# Altium schematic sheet 02 DAC_AD5760.SchDoc
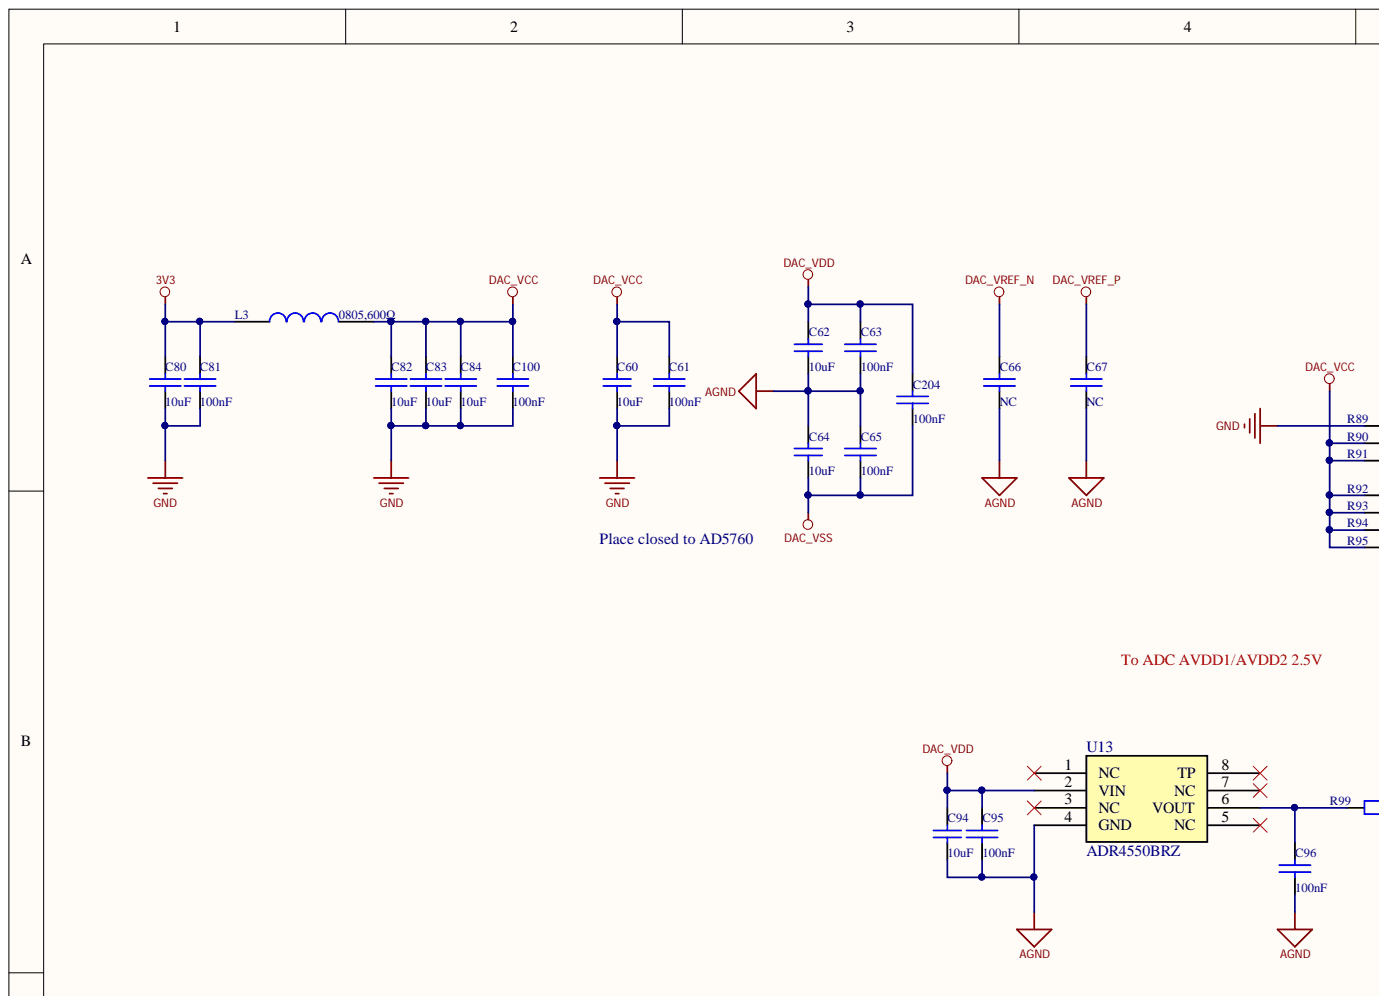
[diagram p0: 02 DAC_AD5760.SchDoc, top left]
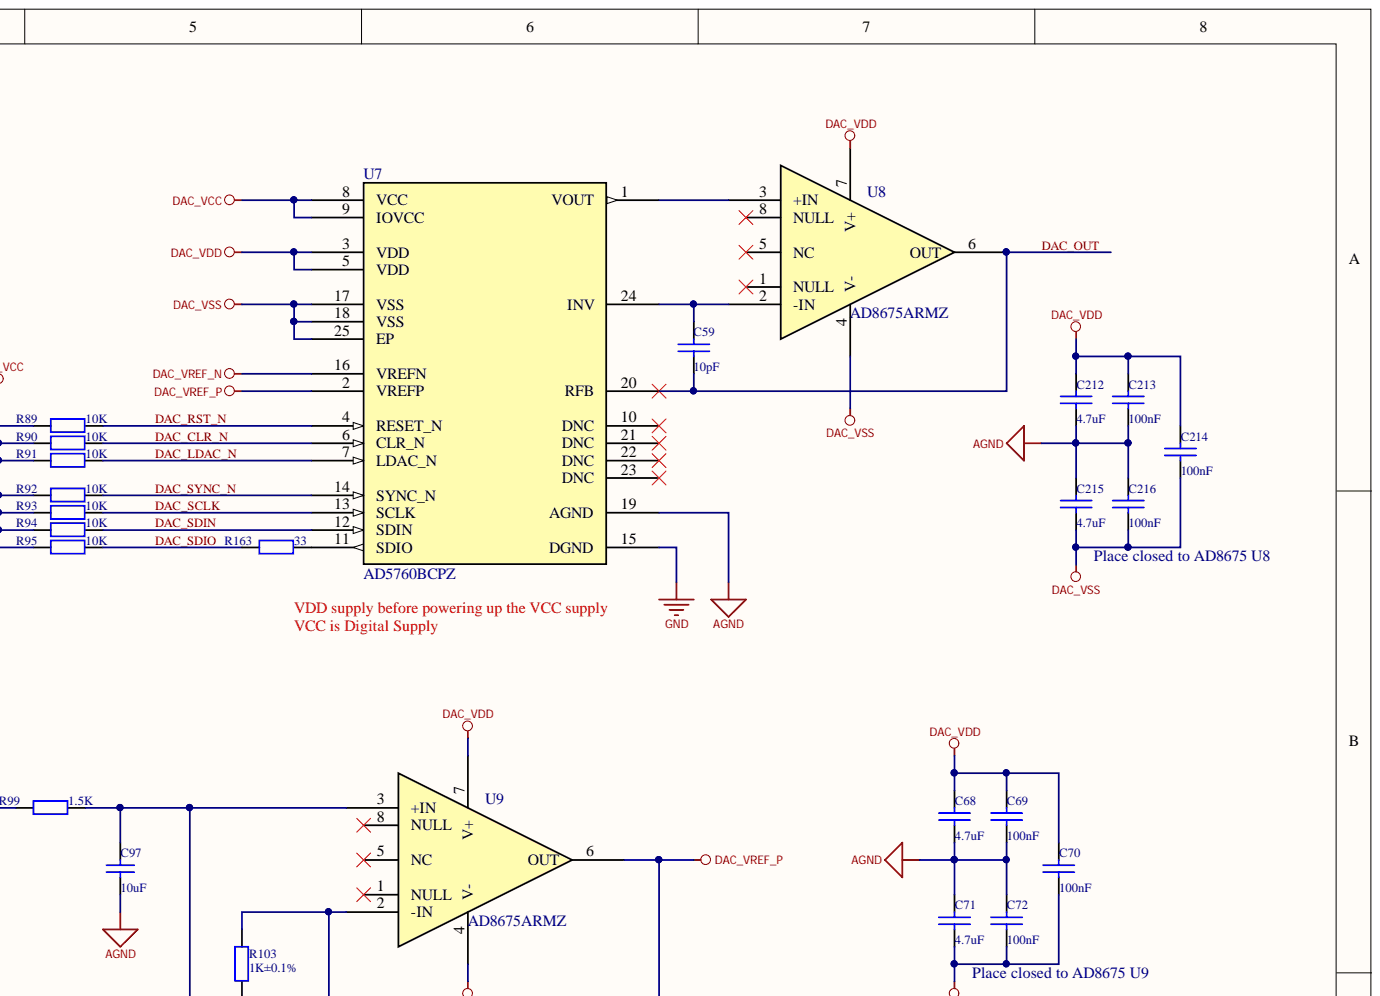
[diagram p1: 02 DAC_AD5760.SchDoc, top right]
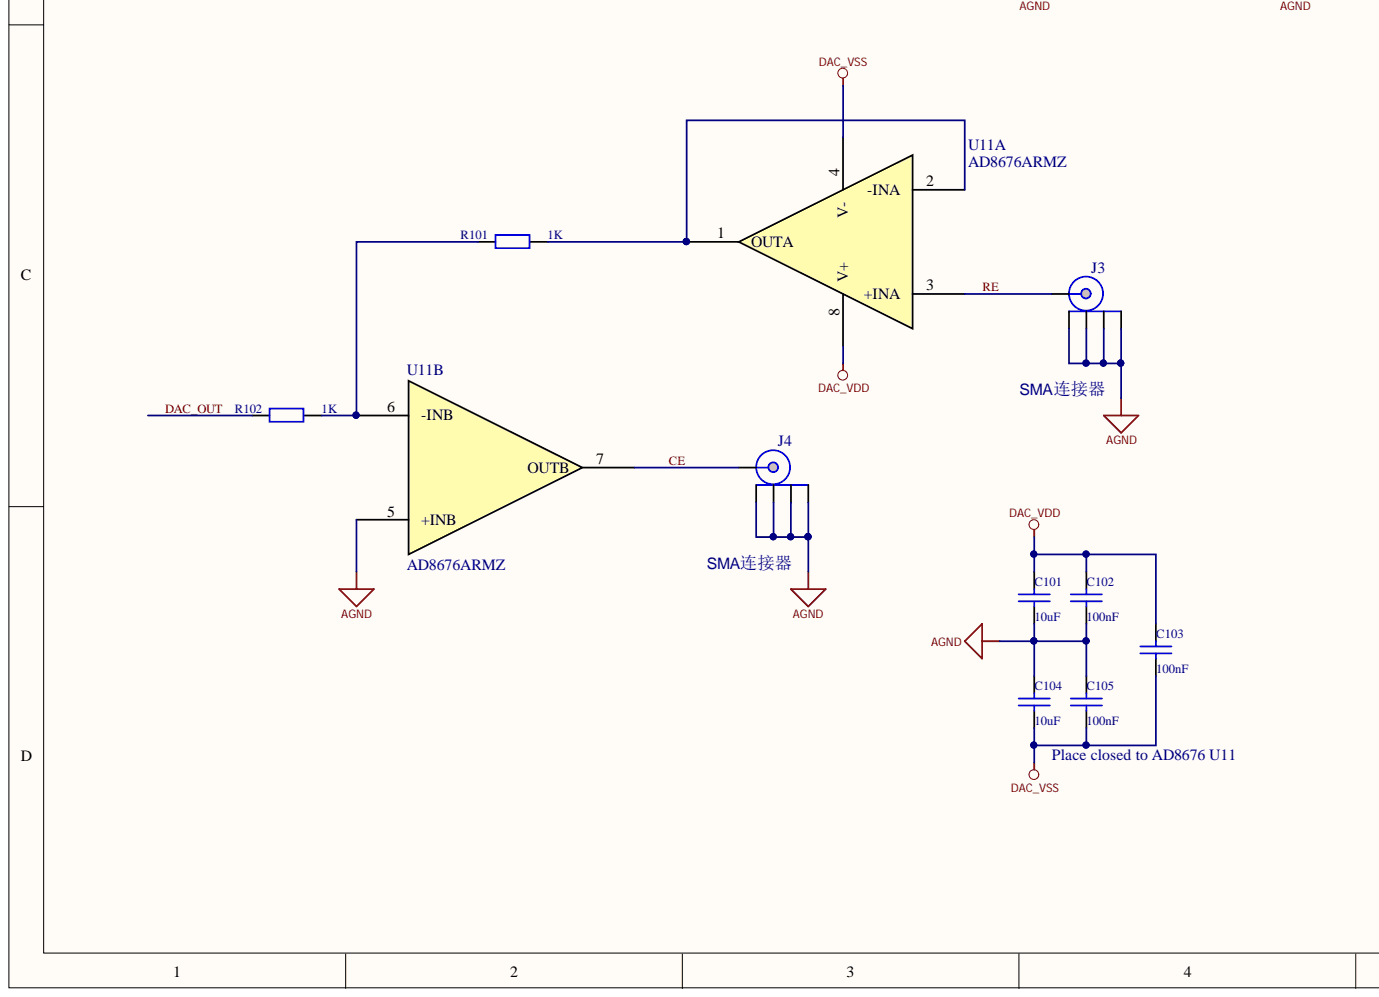
[diagram p2: 02 DAC_AD5760.SchDoc, bottom left]
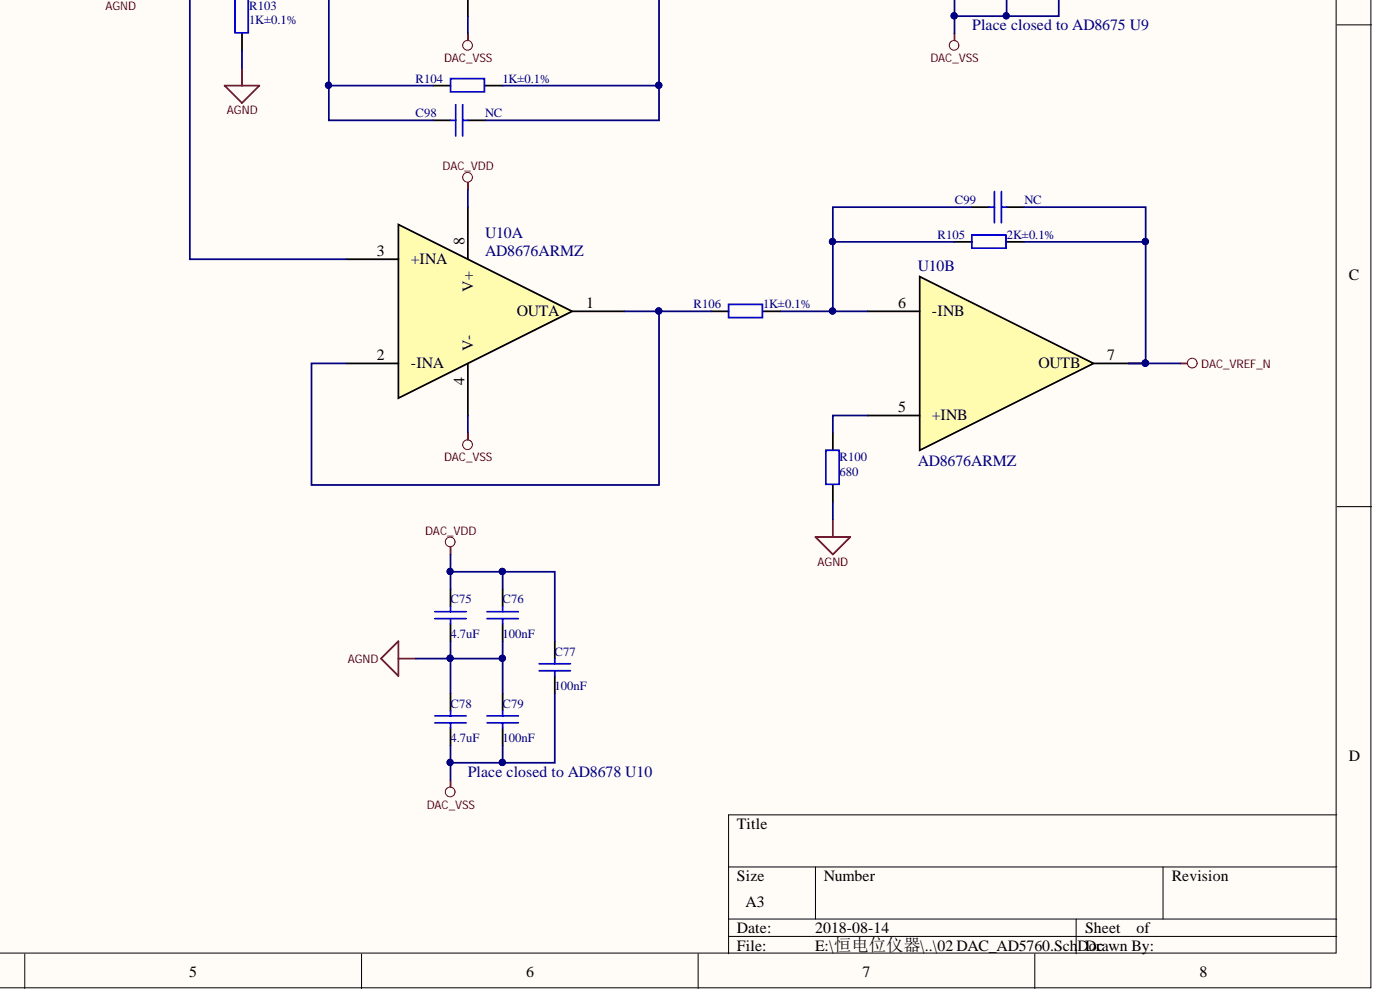
[diagram p3: 02 DAC_AD5760.SchDoc, bottom right]

## Parts
C59  10pF  [SC0402]
C60  10uF  [SC0805]
C61  100nF  [SC0603]
C62  10uF  [SC0805]
C63  100nF  [SC0603]
C64  10uF  [SC0805]
C65  100nF  [SC0603]
C66  220pF  [SC0402]  (value not drawn)
C67  220pF  [SC0402]  (value not drawn)
C68  4.7uF  [SC0603]
C69  100nF  [SC0402]
C70  100nF  [SC0402]
C71  4.7uF  [SC0603]
C72  100nF  [SC0402]
C75  4.7uF  [SC0603]
C76  100nF  [SC0402]
C77  100nF  [SC0402]
C78  4.7uF  [SC0603]
C79  100nF  [SC0402]
C80  10uF  [SC0603]
C81  100nF  [SC0402]
C82  10uF  [SC0603]
C83  10uF  [SC0603]
C84  10uF  [SC0603]
C94  10uF  [SC0805]
C95  100nF  [SC0603]
C96  100nF  [SC0603]
C97  10uF  [SC0805]
C98  100nF  [SC0603]  (value not drawn)
C99  100nF  [SC0603]  (value not drawn)
C100  100nF  [SC0402]
C101  10uF  [SC0603]
C102  100nF  [SC0402]
C103  100nF  [SC0402]
C104  10uF  [SC0603]
C105  100nF  [SC0402]
C204  100nF  [SC0402]
C212  4.7uF  [SC0603]
C213  100nF  [SC0402]
C214  100nF  [SC0402]
C215  4.7uF  [SC0603]
C216  100nF  [SC0402]
J3  SMA连接器  [SMA]
J4  SMA连接器  [SMA]
L3  0805,600Ω  [SL0805]
R89  10K±1%  value 10K  [SR0402]
R90  10K±1%  value 10K  [SR0402]
R91  10K±1%  value 10K  [SR0402]
R92  10K±1%  value 10K  [SR0402]
R93  10K±1%  value 10K  [SR0402]
R94  10K±1%  value 10K  [SR0402]
R95  10K±1%  value 10K  [SR0402]
R99  value 1.5K  [SR0805]  (hidden comment: 1.5K±1%)
R100  value 680  [SR0603]  (hidden comment: 680±1%)
R101  value 1K  [SR0603]  (hidden comment: 1K±1%)
R102  value 1K  [SR0603]  (hidden comment: 1K±1%)
R103  1K±0.1%  [SR0805]
R104  1K±0.1%  [SR0805]
R105  2K±0.1%  [SR0805]
R106  1K±0.1%  [SR0805]
R163  value 33  [SR0402]  (hidden comment: 33±1%)
U7  AD5760BCPZ  [CP-24-5]
U8  AD8675ARMZ  [RM_8]
U9  AD8675ARMZ  [RM_8]
U10  AD8676ARMZ  [RM_8]  (parts A,B)
U11  AD8676ARMZ  [RM_8]  (parts A,B)
U13  ADR4550BRZ  [R_8]

## Nets
3V3: C80.2 C81.2 L3.1
AGND: C62.2 C63.2 C64.2 C65.2 C66.1 C67.1 C68.1 C69.1 C71.2 C72.2 C75.1 C76.1 C78.2 C79.2 C94.2 C95.2 C96.2 C97.2 C101.1 C102.1 C104.2 C105.2 C212.1 C213.1 C215.2 C216.2 J3.2 J3.3 J3.4 J3.5 J4.2 J4.3 J4.4 J4.5 R100.1 R103.1 U7.19(AGND) U11.5(+INB) U13.4(GND)
DAC_VCC: C60.1 C61.1 C82.2 C83.2 C84.2 C100.2 L3.2 R90.2 R91.2 R92.2 R93.2 R94.2 R95.2 U7.8(VCC) U7.9(IOVCC)
DAC_VDD: C62.1 C63.1 C68.2 C69.2 C70.2 C75.2 C76.2 C77.2 C94.1 C95.1 C101.2 C102.2 C103.2 C204.2 C212.2 C213.2 C214.2 U7.3(VDD) U7.5(VDD) U8.7(V+) U9.7(V+) U10.8(V+) U11.8(V+) U13.2(VIN)
DAC_VREF_N: C66.2 C99.2 R105.2 U7.16(VREFN) U10.7(OUTB)
DAC_VREF_P: C67.2 C98.2 R104.2 U7.2(VREFP) U9.6(OUT)
DAC_VSS: C64.1 C65.1 C70.1 C71.1 C72.1 C77.1 C78.1 C79.1 C103.1 C104.1 C105.1 C204.1 C214.1 C215.1 C216.1 U7.17(VSS) U7.18(VSS) U7.25(EP) U8.4(V-) U9.4(V-) U10.4(V-) U11.4(V-)
GND: C60.2 C61.2 C80.1 C81.1 C82.1 C83.1 C84.1 C100.1 R89.2 U7.15(DGND)
CE: J4.1 U11.7(OUTB)
DAC_CLR_N: R90.1 U7.6(CLR_N)
DAC_LDAC_N: R91.1 U7.7(LDAC_N)
DAC_OUT: C59.1 R102.1 U7.20(RFB) U8.6(OUT)
DAC_RST_N: R89.1 U7.4(RESET_N)
DAC_SCLK: R93.1 U7.13(SCLK)
DAC_SDIN: R94.1 U7.12(SDIN)
DAC_SDIO: R95.1 R163.1
DAC_SYNC_N: R92.1 U7.14(SYNC_N)
RE: J3.1 U11.3(+INA)
NetC59_2: C59.2 U7.24(INV) U8.2(-IN)
NetC96_1: C96.1 R99.2 U13.6(VOUT)
NetC97_1: C97.1 R99.1 U9.3(+IN) U10.3(+INA)
NetC98_1: C98.1 R103.2 R104.1 U9.2(-IN)
NetC99_1: C99.1 R105.1 R106.2 U10.6(-INB)
NetR100_2: R100.2 U10.5(+INB)
NetR101_1: R101.1 R102.2 U11.6(-INB)
NetR101_2: R101.2 U11.1(OUTA) U11.2(-INA)
NetR106_1: R106.1 U10.1(OUTA) U10.2(-INA)
NetR163_2: R163.2 U7.11(SDIO)
NetU7_1: U7.1(VOUT) U8.3(+IN)

Not connected: U7 10(DNC) 21(DNC) 22(DNC) 23(DNC); U8 1(NULL) 5(NC) 8(NULL); U9 1(NULL) 5(NC) 8(NULL); U13 1(NC) 3(NC) 5(NC) 7(NC) 8(TP)

## Library data of the parts
C59 = CAP: Capacitor; Part_Comments: SMD陶瓷电容-50V-10pF-+/-5%-NPO-0402; Code: 101024500034; COMPONENT_HIGH_MAX: 0.55MM; DEVICE: CC0402JRNPO9BN100; Vendor: Yageo; Voltage: 50V; Component Kind: Standard; Value: 10pF
C60 C62 C64 C94 C97 = Cap Semi: Capacitor; Part_Comments: SMD陶瓷电容-25V-10uF-+/-10%-X5R-0805; Code: 101024500042; DEVICE: CC0805KKX5R8BB106; Vendor: Yageo; Voltage: 25V; Component Kind: Standard; Library Name: Miscellaneous Devices.IntLib; Signal Integrity: Cap Semi; Simulation: CAP; Value: 10uF
C61 C63 C65 C95 C96 C98 C99 = CAP: Capacitor; Part_Comments: SMD陶瓷电容-50V-100nF-+/-10%-X7R-0603; COMPONENT_HIGH_MAX: 0.55MM; DEVICE: CC0603KRX7R9BB104; Vendor: Yageo; Voltage: 50V; Component Kind: Standard; Value: 100nF
  C98 C99: NC: NC
C66 C67 = CAP: Capacitor; Part_Comments: SMD陶瓷电容-50V-220pF-+/-10%-X7R-0402; Code: 101024500032; COMPONENT_HIGH_MAX: 0.55MM; DEVICE: CC0402KRX7R9BB221; Vendor: Yageo; Voltage: 50V; Component Kind: Standard; NC: NC; Value: 220pF
C68 C71 C75 C78 C212 C215 = CAP: Capacitor; Part_Comments: SMD陶瓷电容-16V-4.7uF-+/-10%-X5R-0603; DEVICE: CC0603KRX5R7BB475; Vendor: Yageo; Voltage: 16V; Component Kind: Standard; Value: 4.7uF
C69 C70 C72 C76 C77 C79 C81 C100 C102 C103 C105 C204 C213 C214 C216 = CAP: Capacitor; Part_Comments: SMD陶瓷电容-10V-100nF-+/-10%-X7R-040; Code: 101024500028; COMPONENT_HIGH_MAX: 0.55MM; DEVICE: CC0402KRX5R6BB104; Vendor: Yageo; Voltage: 10V; Component Kind: Standard; Value: 100nF
C80 C82-C84 C101 C104 = CAP: Capacitor; Part_Comments: SMD陶瓷电容-6.3V-10uF-+/-20%-X5R-0603; Code: 101024500091; COMPONENT_HIGH_MAX: 0.9MM; DEVICE: CC0603MRX5R5BB106; Vendor: Yageo; Voltage: 6.3V; Component Kind: Standard; Value: 10uF
J3 J4 = CON\SMA-END_1: CONN, BNC, SMA 50-OHM EDGE-LANCH; Part Number: E.F.JOHNSON, 142-0701-851; Component Kind: Standard; Part_Comments: SMA连接器
L3 = INDUCTOR: 贴片磁珠频率-600Ω-±25%-0.25Ω-1.5A-0805; Code: 101037512601; Device: PZ2012U601; Value: PZ2012U601; Vendor: Sunlord; Component Kind: Standard; Part_Comments: 贴片磁珠频率-600Ω-±25%-0.25Ω-1.5A-0805
R89-R95 = RES2: Resistor; Part_Comments: 片式厚膜电阻器-0.0625W-10KOHM-+/-1%-0402; Code: 101017400055; DEVICE: RC0402FR-0710KL; Vendor: Yageo; Component Kind: Standard
R99 = RES2: Resistor; Part_Comments: 片式厚膜电阻器-0.125W-1.5KOHM-+/-1%-0805; Code: 101017515200; DEVICE: RC0805FR-071K5L; Vendor: Yageo; Component Kind: Standard
R100 = Res2: Resistor; Part_Comments: 片式厚膜电阻器-0.1W-680OHM-+/-1%-0603; DEVICE: RC0603FR-07680RL; Vendor: Yageo; Component Kind: Standard; Library Name: Miscellaneous Devices.IntLib
R101 R102 = Res2: Resistor; Part_Comments: 片式厚膜电阻器-0.1W-1KOHM-+/-1%-0603; Code: 101017310070; DEVICE: RC0603FR-071KL; Vendor: Yageo; Component Kind: Standard; Library Name: Miscellaneous Devices.IntLib
R103 R104 R106 = Res2: Resistor; Part_Comments: 薄膜电阻器-0.1W-1KOHM-+/-0.1%-0805-5ppm; DEVICE: PCF0805-13-1K0BT1; Value: 1K; Vendor: TT Electronics; Component Kind: Standard; Library Name: Miscellaneous Devices.IntLib
R105 = Res2: Resistor; Part_Comments: 薄膜电阻器-0.1W-2KOHM-+/-0.1%-0805-5ppm; DEVICE: PCF0805-13-2K0BT1; Value: 2K; Vendor: TT Electronics; Component Kind: Standard; Library Name: Miscellaneous Devices.IntLib
R163 = Res2: Resistor; Part_Comments: 片式厚膜电阻器-0.0625W-33OHM-+/-; Code: 101017400043; DEVICE: RC0402FR-0733RL; Vendor: Yageo; Component Kind: Standard; Library Name: Miscellaneous Devices.IntLib; Signal Integrity: Res; Simulation: RESISTOR
U7 = AD5760BCPZ: Ultra Stable, 16-Bit ±0.5 LSB INL,Voltage Output DAC; Vendor: ADI; Component Kind: Standard; Value: AD5760BCPZ; Library Name: Potentiostat.SchLib
U8 U9 = AD8675ARMZ: 36 V Precision, 2.8 nV/√Hz Rail-to-Rail Output Op Amp; Vendor: ADI; Component Kind: Standard; Value: AD8675ARMZ; Library Name: Potentiostat.SchLib
U10 U11 = AD8676ARMZ: 36 V Precision, 2.8 nV/√Hz Rail-to-Rail Output Op Amp; Vendor: ADI; Component Kind: Standard; Value: AD8676ARMZ; Library Name: Potentiostat.SchLib
U13 = ADR4550BRZ: Ultralow Noise, High Accuracy Voltage References; Vendor: ADI; Component Kind: Standard; Value: ADR4550BRZ; Library Name: Potentiostat.SchLib
